# Altium schematic sheet UserInterface_0_V1_01.SchDoc
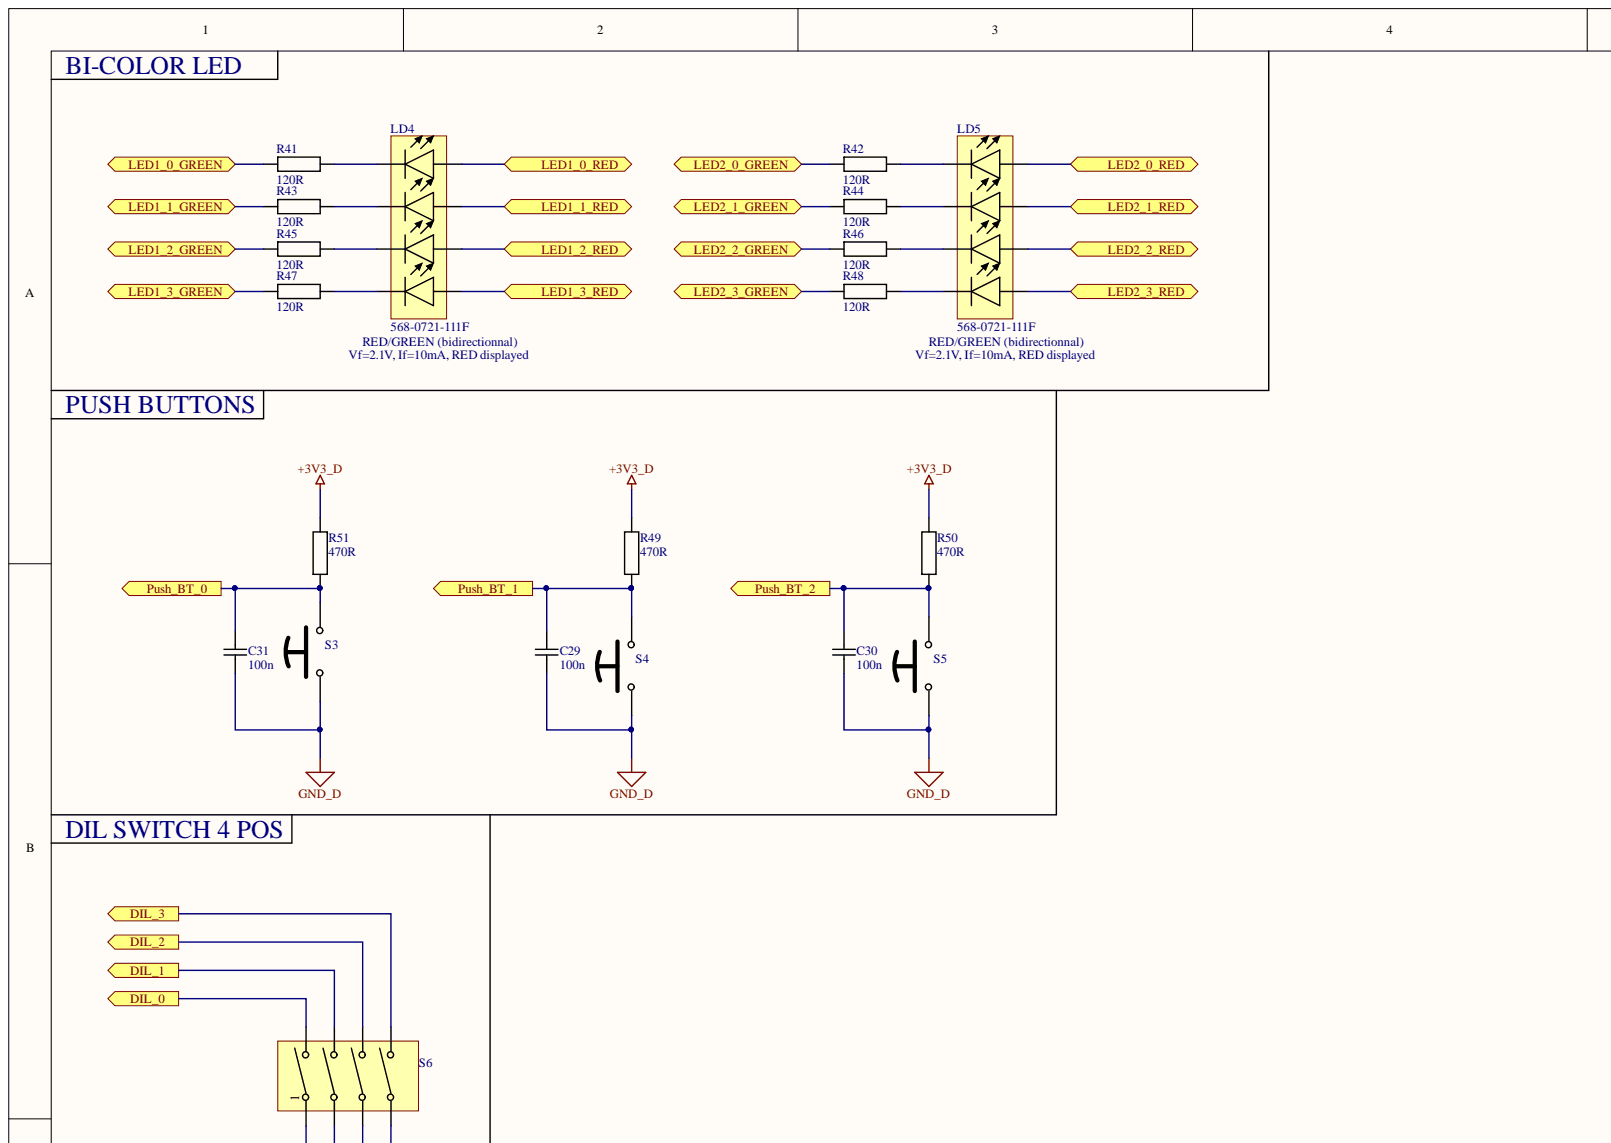
[diagram p0: UserInterface_0_V1_01.SchDoc, top left]
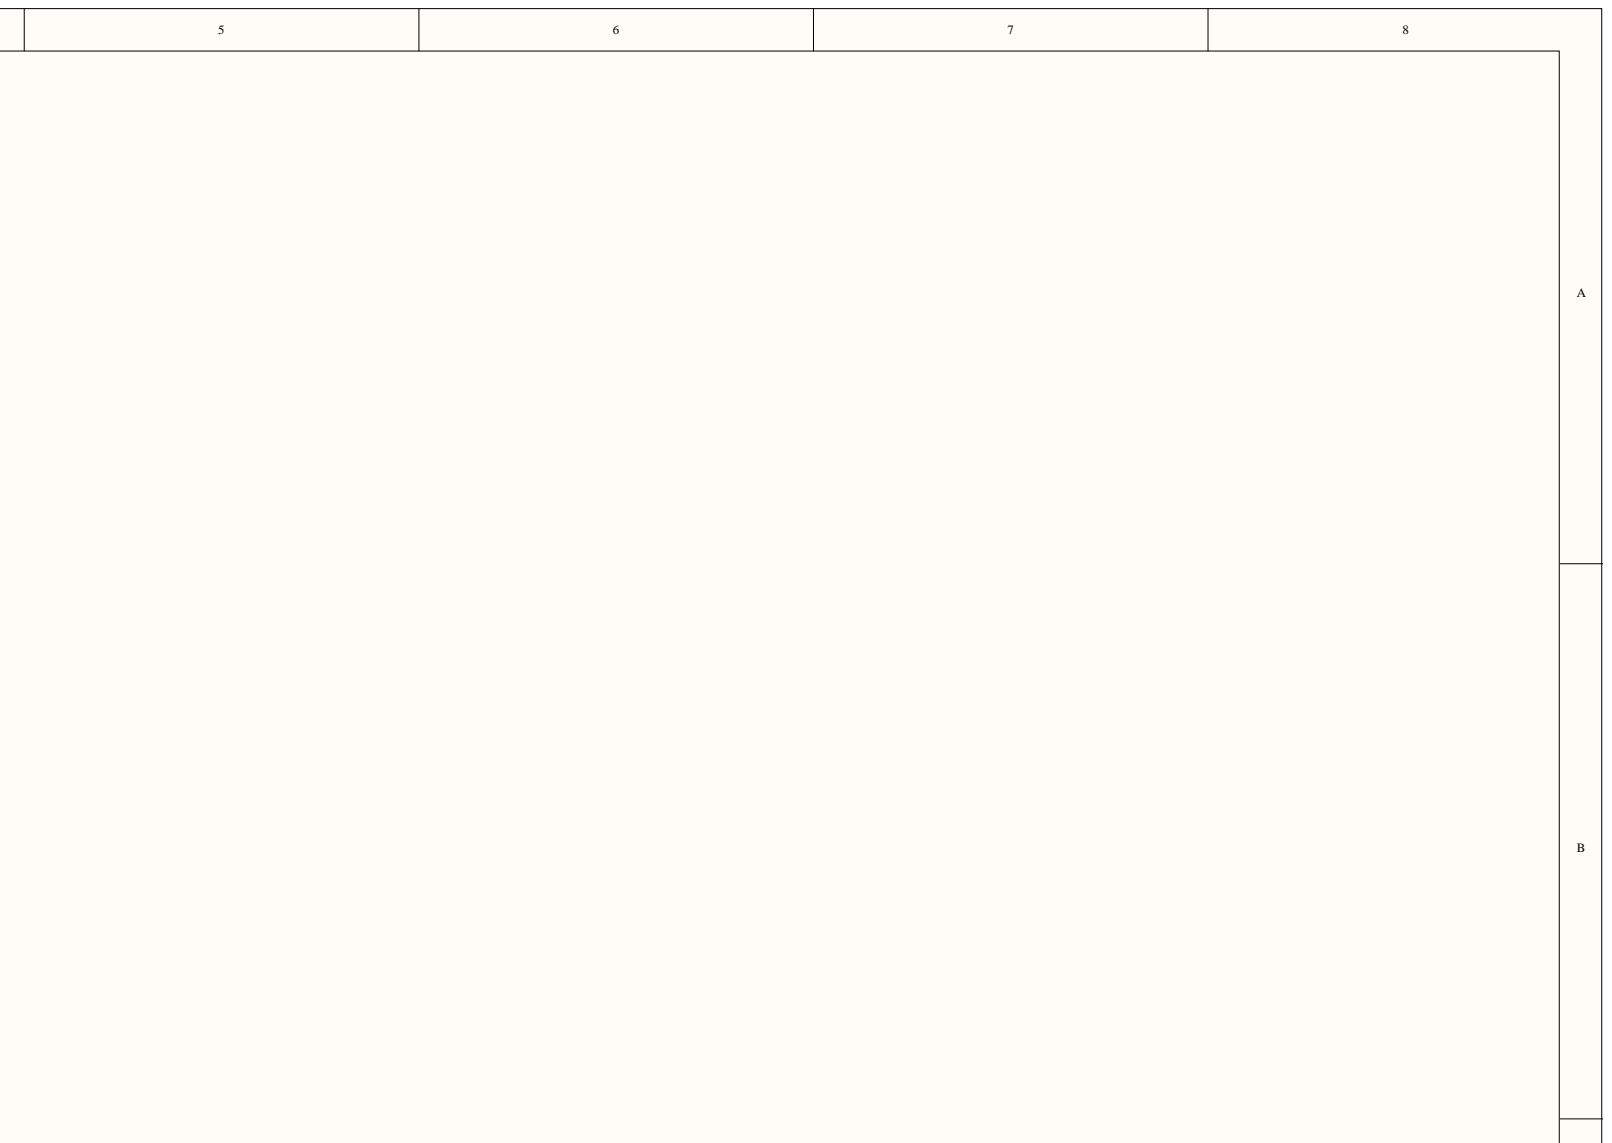
[diagram p1: UserInterface_0_V1_01.SchDoc, top right]
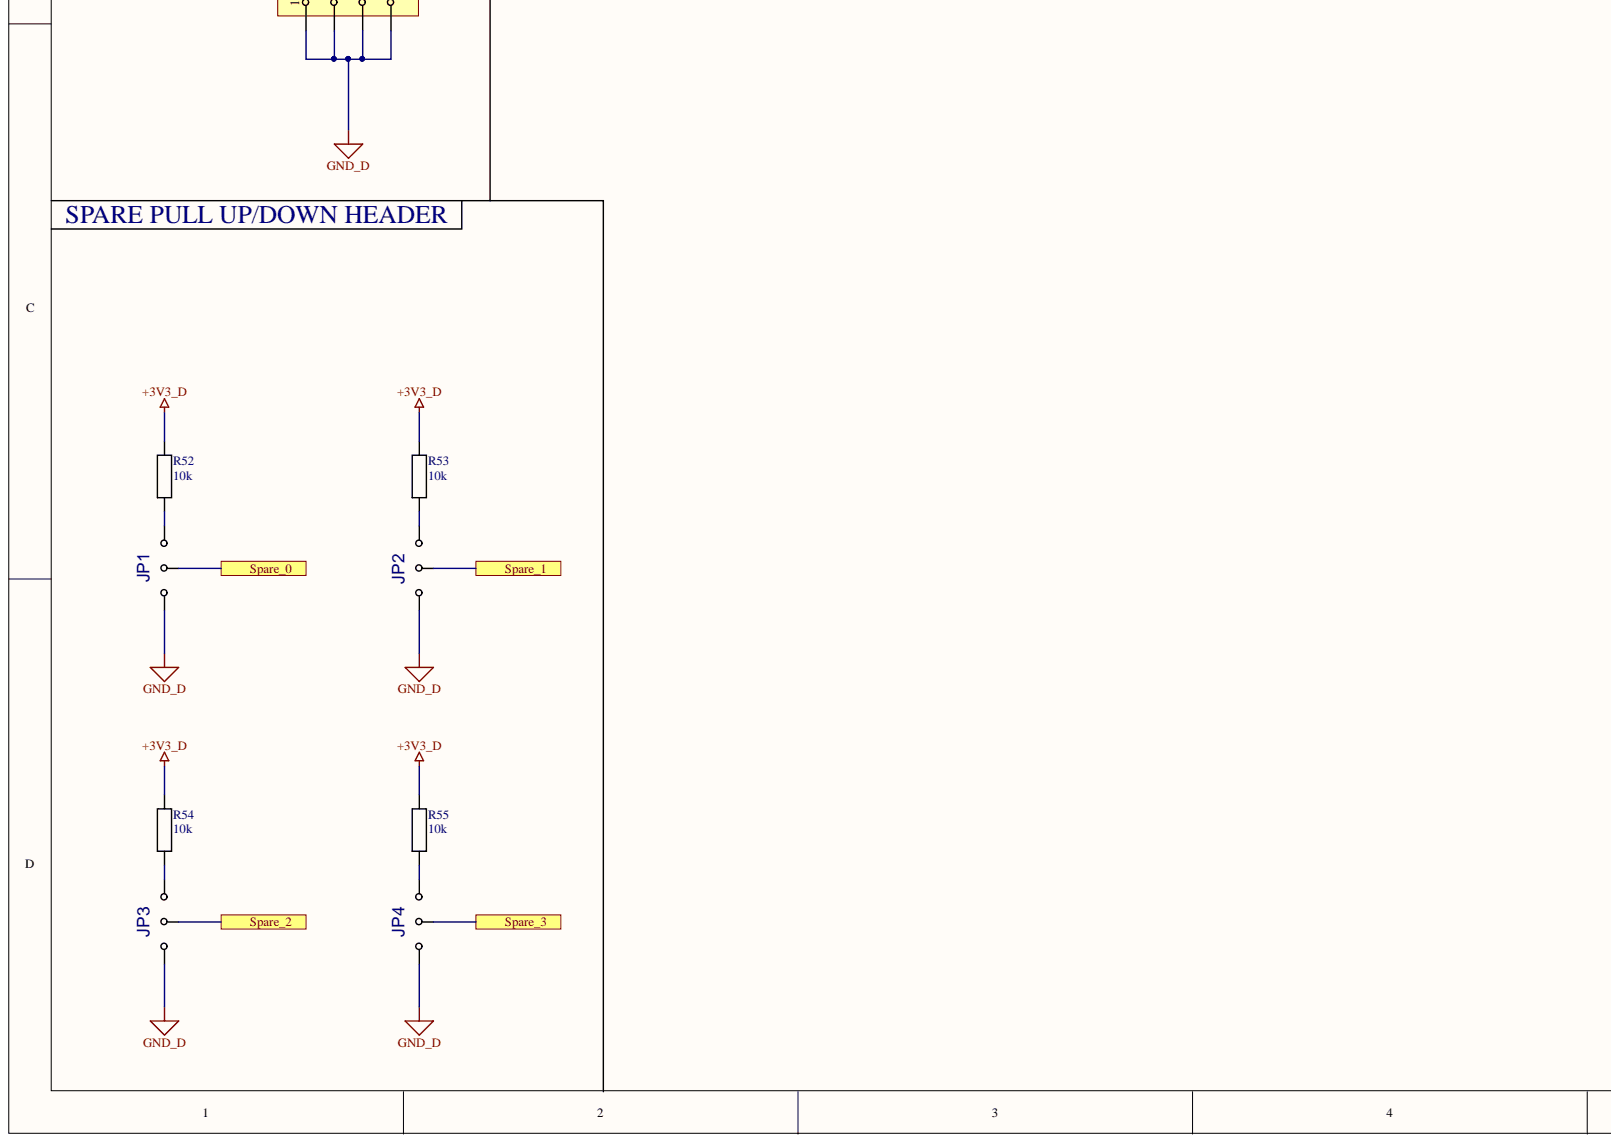
[diagram p2: UserInterface_0_V1_01.SchDoc, bottom left]
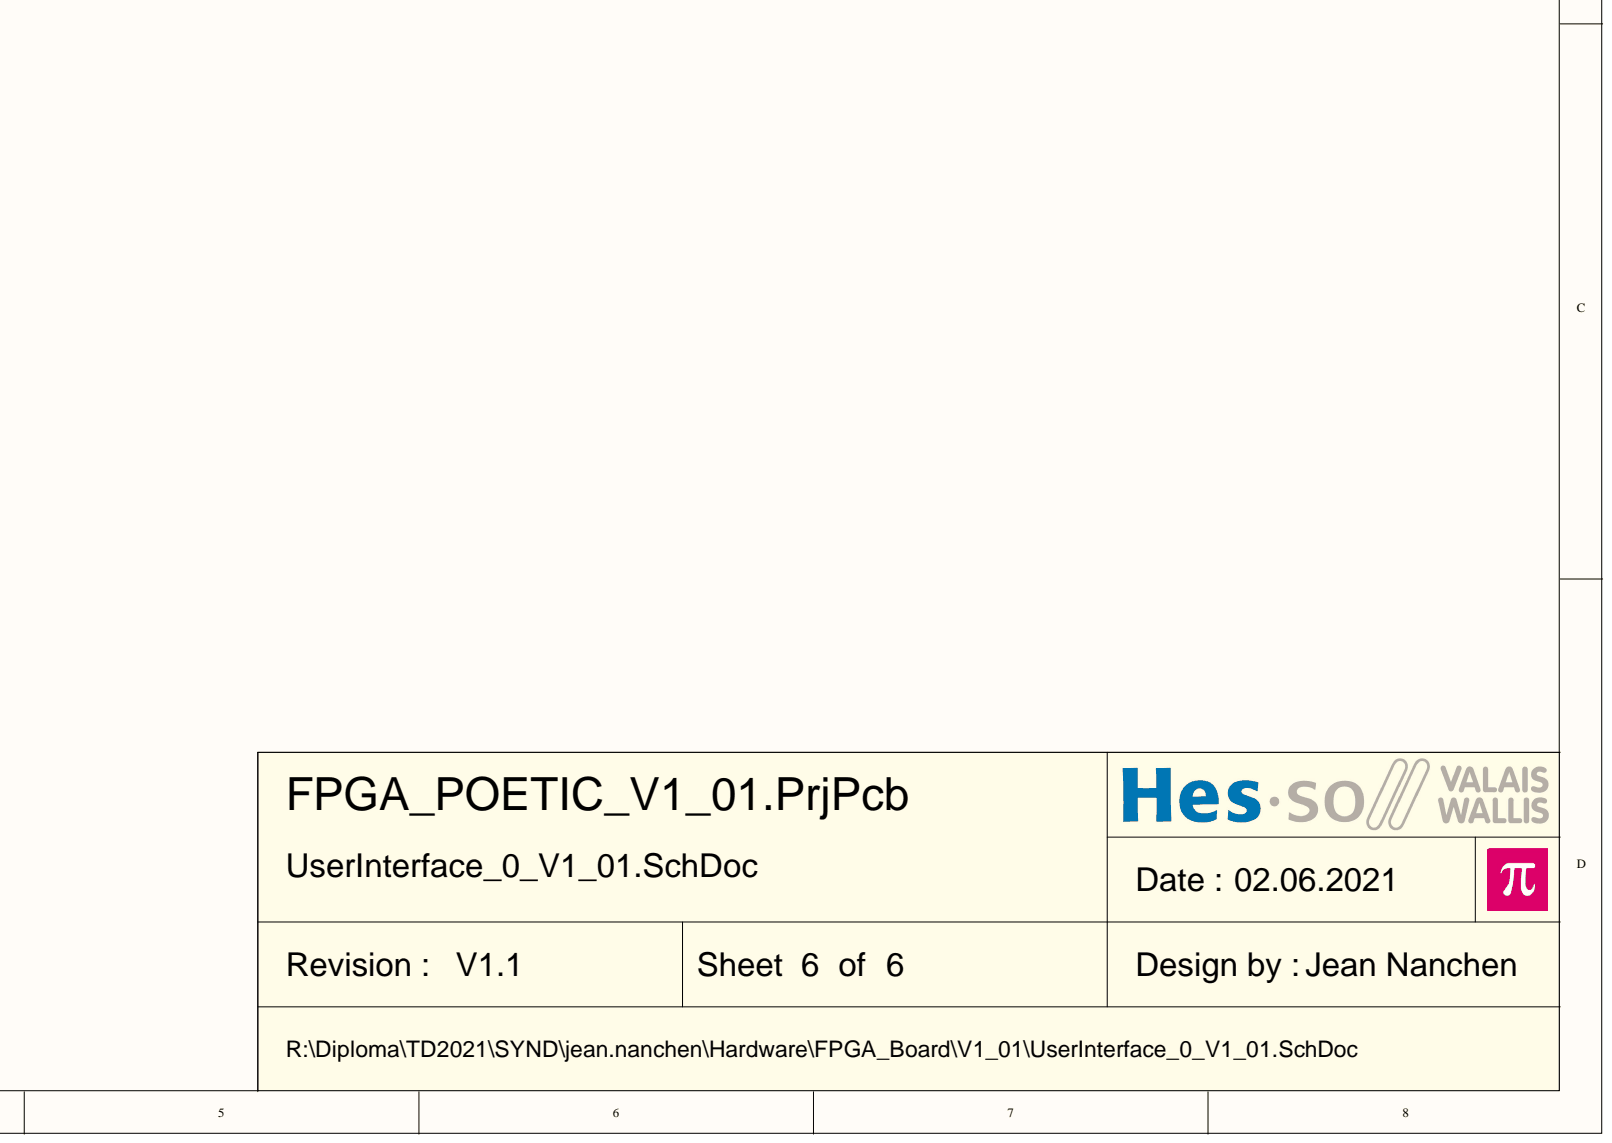
[diagram p3: UserInterface_0_V1_01.SchDoc, bottom right]

## Parts
C29  100n  [CAPACITOR 1608 REFLOW]
C30  100n  [CAPACITOR 1608 REFLOW]
C31  100n  [CAPACITOR 1608 REFLOW]
JP1  {Value}  [JUMPER3 SMD 2012]  (value not drawn)
JP2  {Value}  [JUMPER3 SMD 2012]  (value not drawn)
JP3  {Value}  [JUMPER3 SMD 2012]  (value not drawn)
JP4  {Value}  [JUMPER3 SMD 2012]  (value not drawn)
LD4  568-0721-111F  [LED Dialight 568-0721-111]
LD5  568-0721-111F  [LED Dialight 568-0721-111]
R41  120R  [RESISTOR 1608 REFLOW]
R42  120R  [RESISTOR 1608 REFLOW]
R43  120R  [RESISTOR 1608 REFLOW]
R44  120R  [RESISTOR 1608 REFLOW]
R45  120R  [RESISTOR 1608 REFLOW]
R46  120R  [RESISTOR 1608 REFLOW]
R47  120R  [RESISTOR 1608 REFLOW]
R48  120R  [RESISTOR 1608 REFLOW]
R49  470R  [RESISTOR 1608 REFLOW]
R50  470R  [RESISTOR 1608 REFLOW]
R51  470R  [RESISTOR 1608 REFLOW]
R52  10k  [RESISTOR 1608 REFLOW]
R53  10k  [RESISTOR 1608 REFLOW]
R54  10k  [RESISTOR 1608 REFLOW]
R55  10k  [RESISTOR 1608 REFLOW]
S3  FSMRA4JH04  [Push Button C7.1x3.7 TE FSMRA4JH]  (value not drawn)
S4  FSMRA4JH04  [Push Button C7.1x3.7 TE FSMRA4JH]  (value not drawn)
S5  FSMRA4JH04  [Push Button C7.1x3.7 TE FSMRA4JH]  (value not drawn)
S6  (no value)  [DIP SWITCH MULTICOMP  MCDHN-04F]

## Ports
DIL_0, DIL_1, DIL_2, DIL_3, LED1_0_GREEN, LED1_0_RED, LED1_1_GREEN, LED1_1_RED, LED1_2_GREEN, LED1_2_RED, LED1_3_GREEN, LED1_3_RED, LED2_0_GREEN, LED2_0_RED, LED2_1_GREEN, LED2_1_RED, LED2_2_GREEN, LED2_2_RED, LED2_3_GREEN, LED2_3_RED, Push_BT_0, Push_BT_1, Push_BT_2, Spare_0, Spare_1, Spare_2, Spare_3

## Nets
+3V3_D: R49.2 R50.2 R51.2 R52.2 R53.2 R54.2 R55.2
GND_D: C29.1 C30.1 C31.1 JP1.1 JP2.1 JP3.1 JP4.1 S3.1 S4.1 S5.1 S6.1 S6.3 S6.5 S6.7
DIL_0: S6.2 ; port DIL_0
DIL_1: S6.4 ; port DIL_1
DIL_2: S6.6 ; port DIL_2
DIL_3: S6.8 ; port DIL_3
LED1_0_GREEN: R41.1 ; port LED1_0_GREEN
LED1_0_RED: LD4.8 ; port LED1_0_RED
LED1_1_GREEN: R43.1 ; port LED1_1_GREEN
LED1_1_RED: LD4.6 ; port LED1_1_RED
LED1_2_GREEN: R45.1 ; port LED1_2_GREEN
LED1_2_RED: LD4.4 ; port LED1_2_RED
LED1_3_GREEN: R47.1 ; port LED1_3_GREEN
LED1_3_RED: LD4.2 ; port LED1_3_RED
LED2_0_GREEN: R42.1 ; port LED2_0_GREEN
LED2_0_RED: LD5.8 ; port LED2_0_RED
LED2_1_GREEN: R44.1 ; port LED2_1_GREEN
LED2_1_RED: LD5.6 ; port LED2_1_RED
LED2_2_GREEN: R46.1 ; port LED2_2_GREEN
LED2_2_RED: LD5.4 ; port LED2_2_RED
LED2_3_GREEN: R48.1 ; port LED2_3_GREEN
LED2_3_RED: LD5.2 ; port LED2_3_RED
Push_BT_0: C31.2 R51.1 S3.2 ; port Push_BT_0
Push_BT_1: C29.2 R49.1 S4.2 ; port Push_BT_1
Push_BT_2: C30.2 R50.1 S5.2 ; port Push_BT_2
Spare_0: JP1.2 ; port Spare_0
Spare_1: JP2.2 ; port Spare_1
Spare_2: JP3.2 ; port Spare_2
Spare_3: JP4.2 ; port Spare_3
NetJP1_3: JP1.3 R52.1
NetJP2_3: JP2.3 R53.1
NetJP3_3: JP3.3 R54.1
NetJP4_3: JP4.3 R55.1
NetLD4_1: LD4.1 R47.2
NetLD4_3: LD4.3 R45.2
NetLD4_5: LD4.5 R43.2
NetLD4_7: LD4.7 R41.2
NetLD5_1: LD5.1 R48.2
NetLD5_3: LD5.3 R46.2
NetLD5_5: LD5.5 R44.2
NetLD5_7: LD5.7 R42.2
N00681:

## Library data of the parts
C29-C31 = Capacitor: Capacitor X7R 10% 50V 2012(0805); Component Kind: Standard; Library Name: Capacitor.IntLib; Value: 100n
JP1-JP4 = JUMPER 3 POS: Component Kind: Standard; Description: JUMPER 3 POS; Library Name: Jumper.IntLib; Value: {Value}
LD4 LD5 = LED Dialight 568-0721-111F: Component Kind: Standard; Description: LED Dialight 568-0721-111F; Library Name: Diode.IntLib; Manufacturer: Dialight; Mouser No.: 645-568-0721-111F; Value: 568-0721-111F
R41-R48 = Resistor: Resistor 1% 50V 100mW 1608(0603); Component Kind: Standard; Library Name: Resistor.IntLib; Value: 120R
R49-R55 = Resistor: Resistor 1% 50V 125mW 2012(0805); Component Kind: Standard; Library Name: Resistor.IntLib
  R49-R51: Value: 470R
  R52-R55: Value: 10k
S3-S5 = Push Button 2Pins: Push Button, 2 pins; Manufacturer: TE Connectivity / Alcoswitch; Component Kind: Standard; Library Name: Button.IntLib; Mouser No.: 506-FSMRA4JH04; Value: FSMRA4JH04
S6 = DIP Switch 4Pos: MULTICOMP  MCDHN-04F; Component Kind: Standard; Library Name: Button.IntLib
